# Altium schematic sheet CW308T_AVR_MICRO.SchDoc(CW308T_AVR_MICRO)
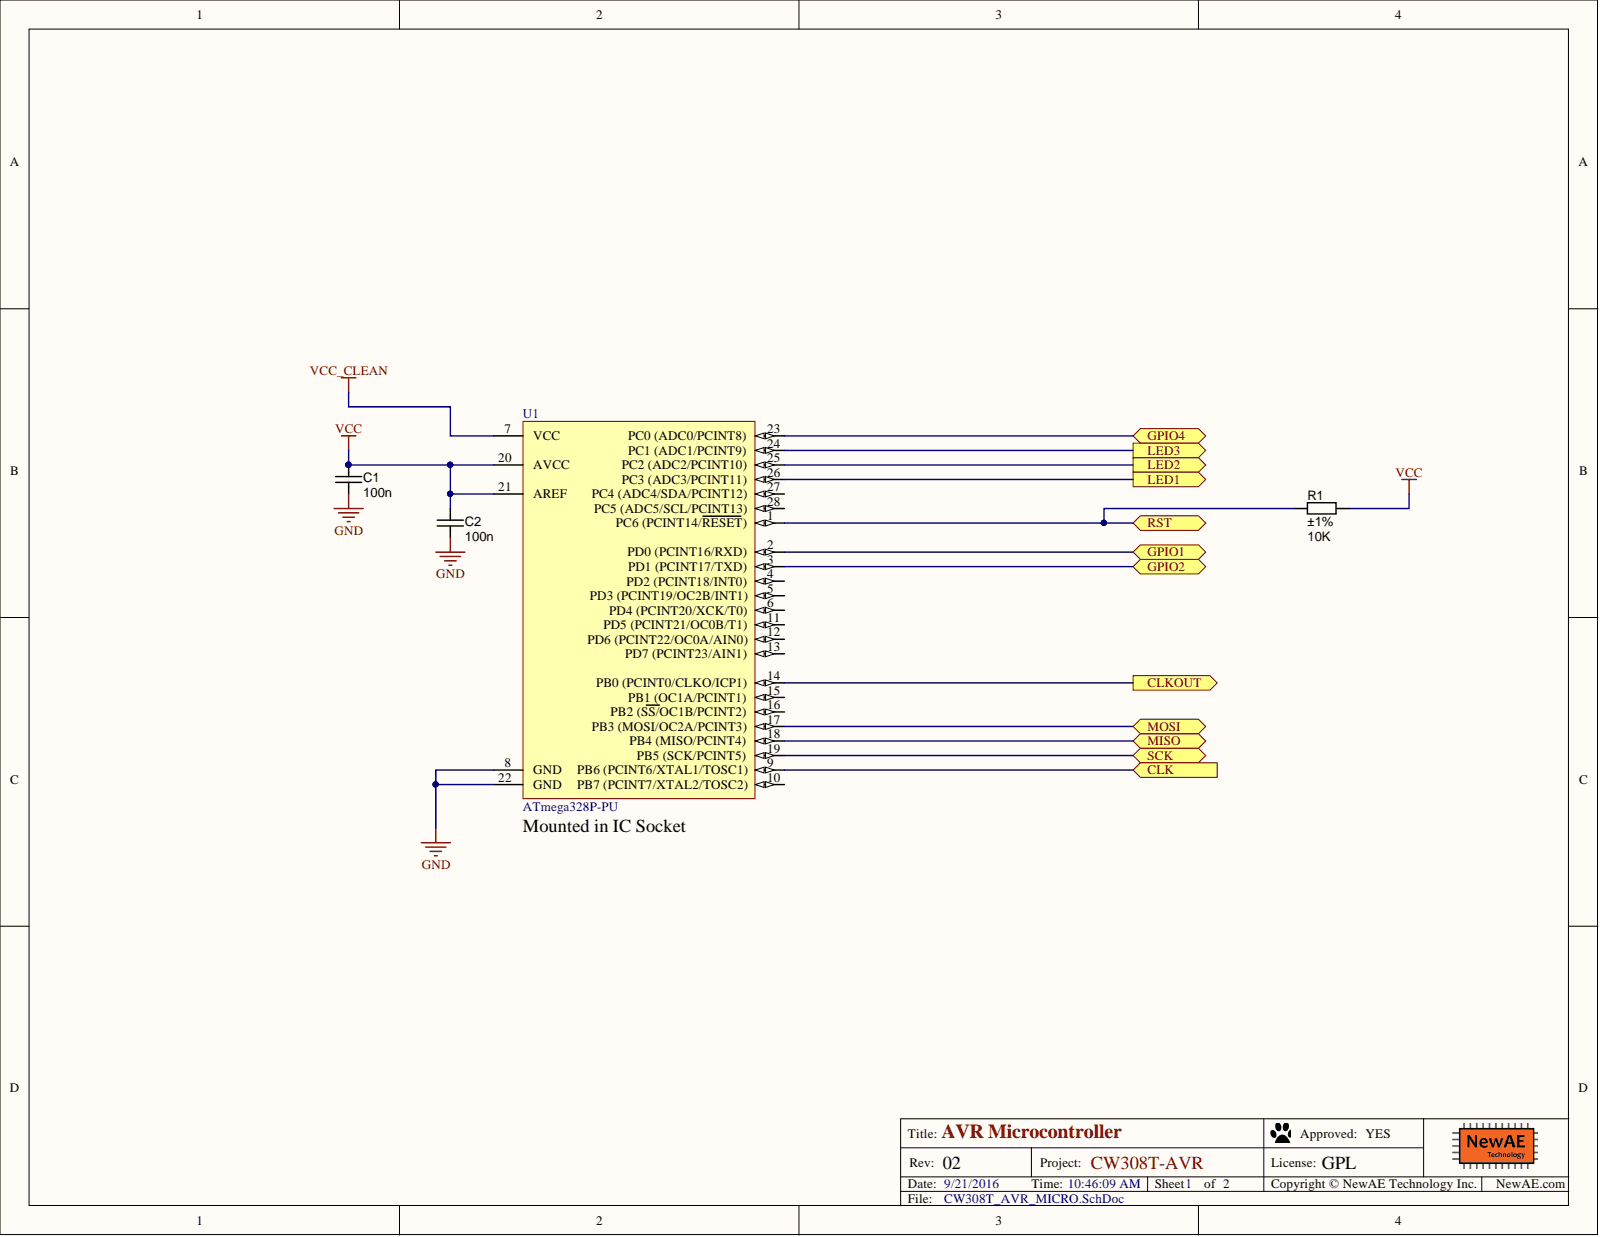
[diagram p0: CW308T_AVR_MICRO.SchDoc]

## Parts
C1  value 100n  [CAPC1608X90N]  (hidden comment: approved sub OK)
C2  value 100n  [CAPC1608X90N]  (hidden comment: approved sub OK)
R1  value 10K  Tolerance: ±1%  [RESC1608X55N]  (hidden comment: Thick Film)
U1  ATmega328P-PU  [28P3]

## Ports
CLK, CLKOUT, GPIO1, GPIO2, GPIO4, LED1, LED2, LED3, MISO, MOSI, RST, SCK

## Nets
GND: C1.2 C2.2 U1.8(GND) U1.22(GND)
VCC: C1.1 C2.1 R1.1 U1.20(AVCC) U1.21(AREF)
VCC_CLEAN: U1.7(VCC)
CLK: U1.9 ; port CLK
CLKOUT: U1.14(PB0_(PCINT0/CLKO/ICP1)) ; port CLKOUT
GPIO1: U1.2(PD0_(PCINT16/RXD)) ; port GPIO1
GPIO2: U1.3(PD1_(PCINT17/TXD)) ; port GPIO2
GPIO4: U1.23(PC0_(ADC0/PCINT8)) ; port GPIO4
LED1: U1.26(PC3_(ADC3/PCINT11)) ; port LED1
LED2: U1.25 ; port LED2
LED3: U1.24(PC1_(ADC1/PCINT9)) ; port LED3
MISO: U1.18(PB4_(MISO/PCINT4)) ; port MISO
MOSI: U1.17(PB3_(MOSI/OC2A/PCINT3)) ; port MOSI
RST: R1.2 U1.1(PC6_(PCINT14/RESET)) ; port RST
SCK: U1.19(PB5_(SCK/PCINT5)) ; port SCK

Not connected: U1 4(PD2_(PCINT18/INT0)) 5(PD3_(PCINT19/OC2B/INT1)) 6(PD4_(PCINT20/XCK/T0)) 10 11(PD5_(PCINT21/OC0B/T1)) 12(PD6_(PCINT22/OC0A/AIN0)) 13(PD7_(PCINT23/AIN1)) 15(PB1_(OC1A/PCINT1)) 16(PB2_(SS/OC1B/PCINT2)) 27 28(PC5_(ADC5/SCL/PCINT13))

## Library data of the parts
C1 C2 = CAPC_100n_0603_X7R_50V_10%: Capacitor SMD Cer 100nF 10% 50V X7R 0603; Manufacturer 1: AVX Corporation; Manufacturer Part Number 1: 06035C104KAT2A; Material: ceramic; Ratings: 50V; X7R; SCDType: spec; Component Kind: Standard; ComponentLink1Description: http://www.avx.com/docs/Catalogs/cx7r.pdf; ComponentLink1URL: http://www.avx.com/docs/Catalogs/cx7r.pdf; ComponentLink2Description: http://product.tdk.com/capacitor/mlcc/en/documents/mlcc_commercial_general_en.pdf; ComponentLink2URL: http://product.tdk.com/capacitor/mlcc/en/documents/mlcc_commercial_general_en.pdf; Library Name: PBL - Capacitors.SCHLIB; Manufacturer 2: TDK Corporation; Manufacturer Part Number 2: C1608X7R1H104K; Package / Case: 0603 (1608 Metric); Supplier 1: Digi-Key; Supplier 2: Digi-Key; Supplier Part Number 1: 478-5052-1-ND; Supplier Part Number 2: 445-1314-1-ND; Tolerance: ±10%
R1 = RES_10K_0603_1%: Resistor SMD chip 10k Ohm 0.1W 1% 0603; Manufacturer 1: Yageo; Manufacturer Part Number 1: RC0603FR-0710KL; Ratings: 1/10W; SCDType: spec; Component Kind: Standard; Library Name: PBL - Resistors.SCHLIB; Package / Case: 0603 (1608 Metric); Supplier 1: Digi-Key; Supplier Part Number 1: 311-10.0KHRCT-ND
U1 = CMP-0095-00013-2: 8-bit AVR Microcontroller, 32KB Flash, 1KB EEPROM, 2KB SRAM, 28-pin PDIP, Industrial Grade (-40°C to 85°C); Component Kind: Standard; ComponentLink1Description: Manufacturer URL; ComponentLink1URL: http://www.atmel.com/; ComponentLink2Description: ATmega48A/48PA/88A/88PA/168A/168PA/328/328P Datasheet; ComponentLink2URL: http://www.atmel.com/dyn/resources/prod_documents/8271.pdf; ComponentLink3Description: ATmega48A/48PA/88A/88PA/168A/168PA/328/328P Summary; ComponentLink3URL: http://www.atmel.com/dyn/resources/prod_documents/8271S.pdf; DatasheetVersion: revD, May-2011; Library Name: Altium Content Vault; PackageReference: 28P3; PackageVersion: revB, Sep-2001; RevisionNote: DatasheetVersion updated. URLs added.
